# Altium schematic sheet 控制板.SchDoc(控制板)
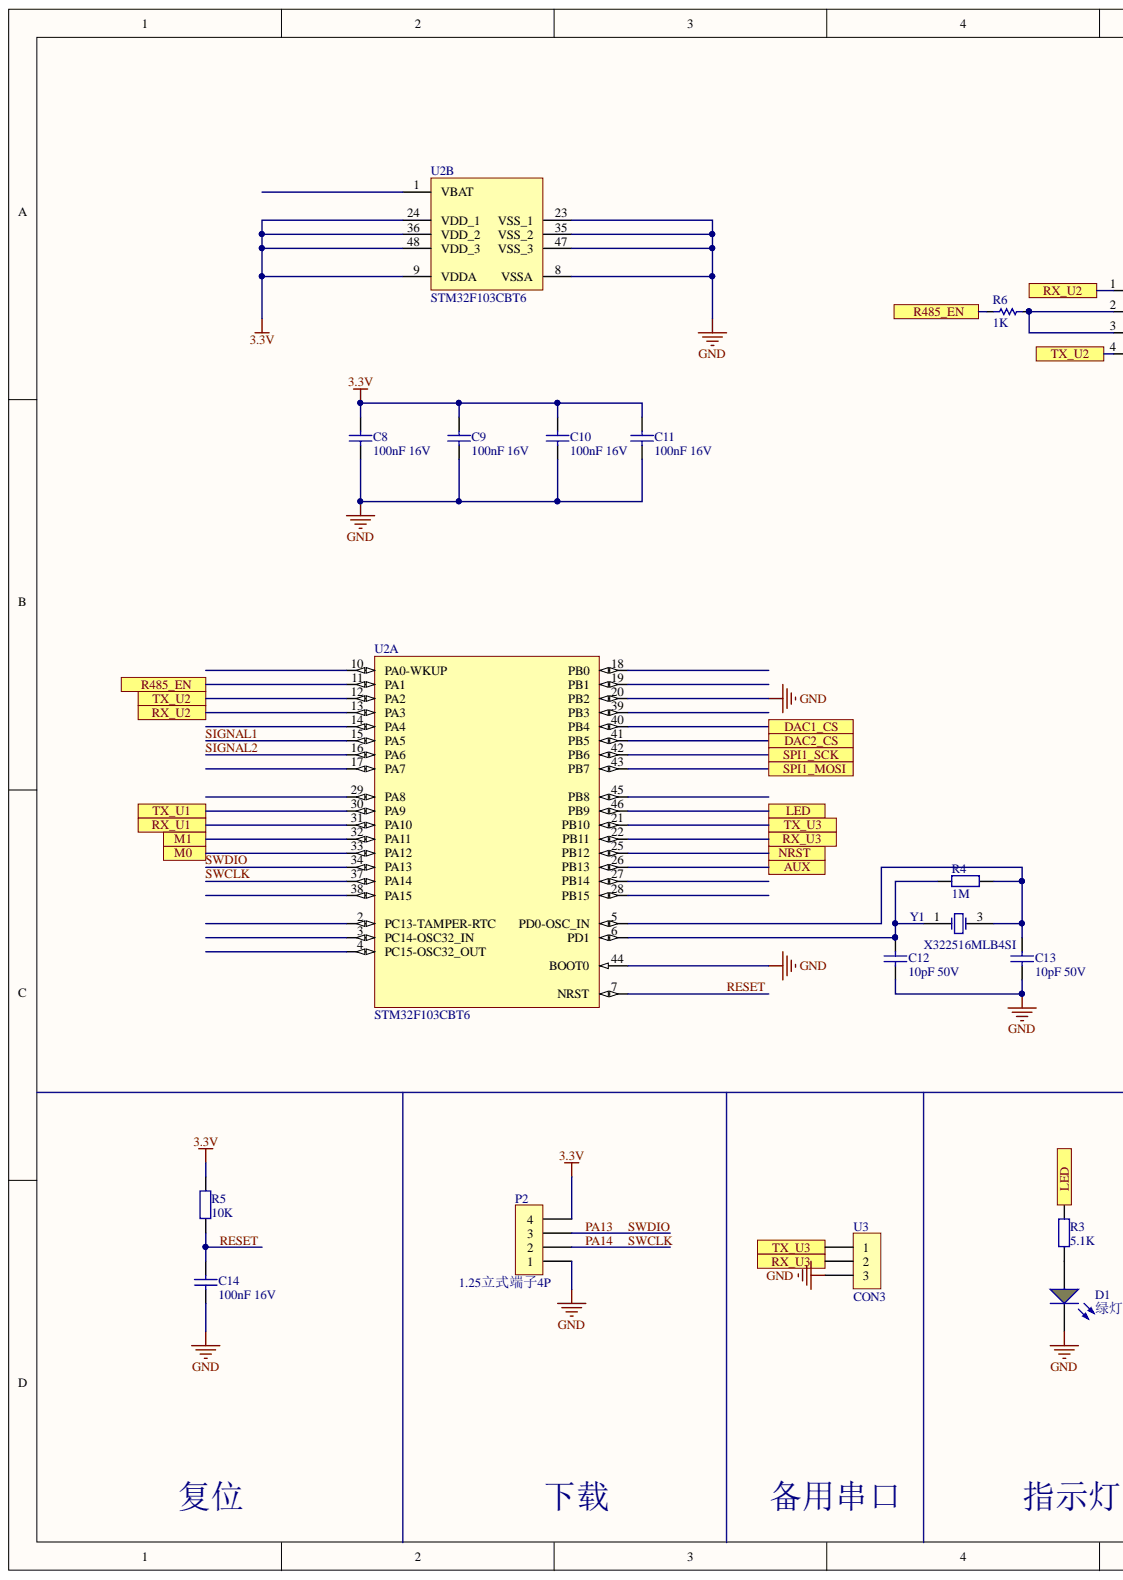
[diagram p0: 控制板.SchDoc, left]
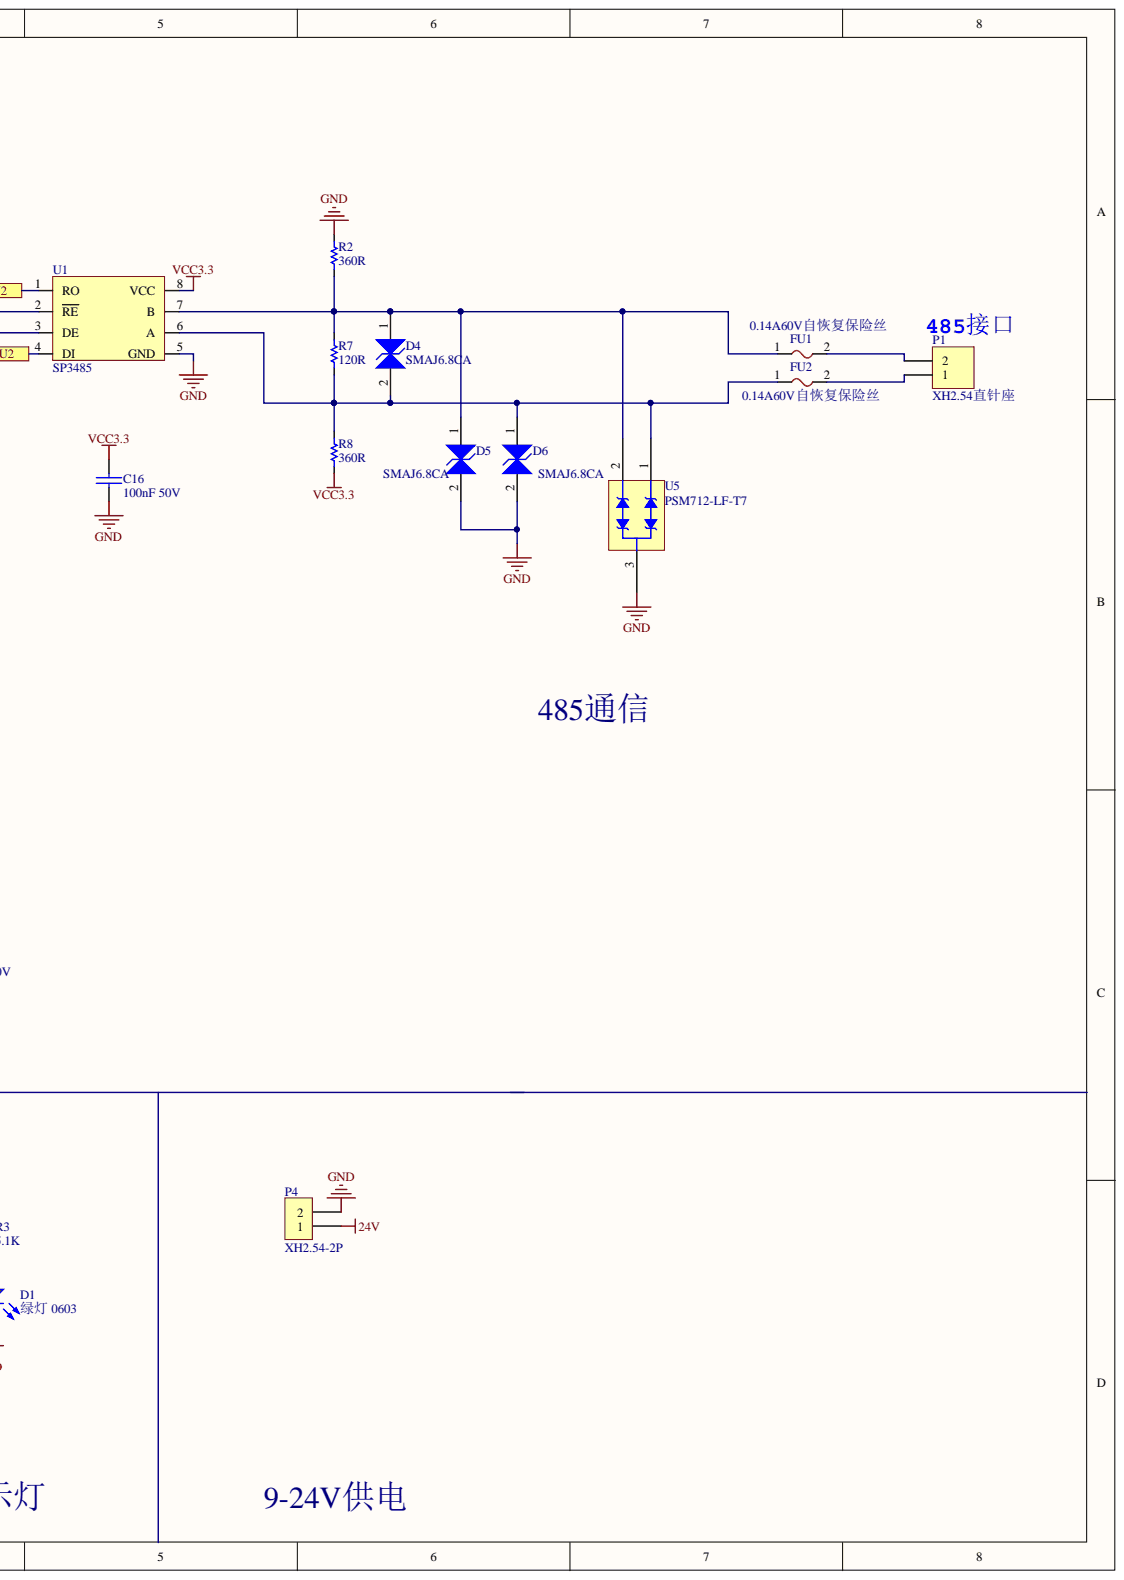
[diagram p1: 控制板.SchDoc, right]

## Parts
C8  100nF 16V  [0603_C]
C9  100nF 16V  [0603_C]
C10  100nF 16V  [0603_C]
C11  100nF 16V  [0603_C]
C12  10pF 50V  [0603_C]
C13  10pF 50V  [0603_C]
C14  100nF 16V  [0603_C]
C16  100nF 50V  [0603_C]
D1  绿灯 0603  Package: 0603  [LC-0603_LED_S1]
D4  SMAJ6.8CA  [SMA(DO-214AC)_S1]
D5  SMAJ6.8CA  [SMA(DO-214AC)_S1]
D6  SMAJ6.8CA  [SMA(DO-214AC)_S1]
FU1  0.14A60V自恢复保险丝  [1812_R]
FU2  0.14A60V自恢复保险丝  [1812_R]
P1  XH2.54直针座  [5.0-2P]
P2  1.25立式端子4P  [1.2.5/4PM]
P4  XH2.54-2P  [2.54/2PM]
R2  360R  [0603_R]
R3  5.1K  [0603_R]
R4  1M  [0603_R]
R5  10K  [0603_R]
R6  1K  [0603_R]
R7  120R  [0603_R]
R8  360R  [0603_R]
U1  SP3485  [SOIC-8_150mil]
U2  STM32F103CBT6  [LQFP-48_7x7x05P]  (parts A,B)
U3  CON3  [SIP3]
U5  PSM712-LF-T7  [SOT-23(SOT-23-3)]
Y1  X322516MLB4SI  [SMD-3225_4P]

## Ports
AUX, DAC1_CS, DAC2_CS, LED, M0, M1, NRST, R485_EN, RX_U1, RX_U2, RX_U3, SPI1_MOSI, SPI1_SCK, TX_U1, TX_U2, TX_U3

## Nets
3.3V: C8.2 C9.2 C10.2 C11.2 P2.4 R5.2 U2.9(VDDA) U2.24(VDD_1) U2.36(VDD_2) U2.48(VDD_3)
24V: P4.1
GND: C8.1 C9.1 C10.1 C11.1 C12.1 C13.1 C14.1 C16.2 D1.K D5.2 D6.2 P2.1 P4.2 R2.2 U1.5(GND) U2.8(VSSA) U2.20(PB2) U2.23(VSS_1) U2.35(VSS_2) U2.44(BOOT0) U2.47(VSS_3) U3.3 U5.3
VCC3.3: C16.1 R8.1 U1.8(VCC)
AUX: U2.26(PB13) ; port AUX
DAC1_CS: U2.40(PB4) ; port DAC1_CS
DAC2_CS: U2.41(PB5) ; port DAC2_CS
LED: R3.2 U2.46(PB9) ; port LED
M0: U2.33(PA12) ; port M0
M1: U2.32(PA11) ; port M1
NRST: U2.25(PB12) ; port NRST
PA13: P2.3 U2.34(PA13)
PA14: P2.2 U2.37(PA14)
R485_EN: R6.2 U2.11(PA1) ; port R485_EN
RESET: C14.2 R5.1 U2.7(NRST)
RX_U1: U2.31(PA10) ; port RX_U1
RX_U2: U1.1(RO) U2.13(PA3) ; port RX_U2
RX_U3: U2.22(PB11) U3.2 ; port RX_U3
SIGNAL1: U2.15(PA5)
SIGNAL2: U2.16(PA6)
SPI1_MOSI: U2.43(PB7) ; port SPI1_MOSI
SPI1_SCK: U2.42(PB6) ; port SPI1_SCK
TX_U1: U2.30(PA9) ; port TX_U1
TX_U2: U1.4(DI) U2.12(PA2) ; port TX_U2
TX_U3: U2.21(PB10) U3.1 ; port TX_U3
NetC12_2: C12.2 R4.1 U2.6(PD1) Y1.1
NetC13_2: C13.2 R4.2 U2.5(PD0-OSC_IN) Y1.3
NetD1_A: D1.A R3.1
NetD4_1: D4.1 D5.1 FU1.1 R2.1 R7.1 U1.7(B) U5.2
NetD4_2: D4.2 D6.1 FU2.1 R7.2 R8.2 U1.6(A) U5.1
NetFU1_2: FU1.2 P1.2
NetFU2_2: FU2.2 P1.1
NetR6_1: R6.1 U1.2(RE) U1.3(DE)
N00078:

Not connected: U2 1(VBAT) 2(PC13-TAMPER-RTC) 3(PC14-OSC32_IN) 4(PC15-OSC32_OUT) 10(PA0-WKUP) 14(PA4) 17(PA7) 18(PB0) 19(PB1) 27(PB14) 28(PB15) 29(PA8) 38(PA15) 39(PB3) 45(PB8)

## Library data of the parts
C8-C11 C14 = 0402 100nF (104) 10% 16V: 贴片电容; SuppliersPartNumber: C1525; Supplier: LC; Package: 0402; Library Name: Cap.SchLib; ComponentLink1URL: http://www.szlcsc.com/product/details_1877.html; ComponentLink1Description: 供应商链接; Component Kind: Standard
C12 C13 = 0402 10pF (100) 5% 50V: 贴片电容; SuppliersPartNumber: C32949; Supplier: LC; Package: 0402; Library Name: Cap.SchLib; ComponentLink1URL: http://www.szlcsc.com/product/details_33914.html; ComponentLink1Description: 供应商链接; Component Kind: Standard
C16 = CAP: Component Kind: Standard; Library Name: wgh元件库.SCHLIB
D1 = 0603 绿灯: 发光二极管; SuppliersPartNumber: C72043; Supplier: LC; ComponentLink1URL: http://www.szlcsc.com/product/details_73152.html; ComponentLink1Description: 供应商链接; Component Kind: Standard; Library Name: wgh元件库.SCHLIB
D4-D6 = SMB/DO-214AA P6SMB6.8CA: TVS; SuppliersPartNumber: C78395; Supplier: LC; Package: SMB/DO-214AA; Library Name: Diode.SchLib; ComponentLink1URL: http://www.szlcsc.com/product/details_79530.html; ComponentLink1Description: 供应商链接; Component Kind: Standard
FU1 FU2 = 自恢复保险丝: Component Kind: Standard; Library Name: wgh元件库.SCHLIB
P1 = Header 2: Header, 2-Pin; LatestRevisionNote: Re-released for DXP Platform.; LatestRevisionDate: 17-Jul-2002; Component Kind: Standard; Publisher: Altium Limited; Library Name: MAMA.SCHLIB
P2 = CON4: Component Kind: Standard; Library Name: wgh元件库.SCHLIB
P4 = CON2: Component Kind: Standard; Library Name: wgh元件库.SCHLIB
R2 R6-R8 = Res: Resistor; Value: 68.1K; Simulation: RESISTOR; Published: 8-Jun-2000; PackageReference: AXIAL-0.3; PackageDescription: Axial Device, Thru-Hole; 2 Leads; 0.3 in Pin Spacing; LatestRevisionNote: Re-released for DXP Platform.; LatestRevisionDate: 17-Jul-2002; Component Kind: Standard; Publisher: Altium Limited; Library Name: MAMA.SCHLIB
R3 = 0402 1KΩ (1001) 1%: 贴片电阻; SuppliersPartNumber: C11702; Supplier: LC; Package: 0402; Library Name: RES.SchLib; ComponentLink1URL: http://www.szlcsc.com/product/details_12256.html; ComponentLink1Description: 供应商链接; Component Kind: Standard
R4 = 0402 1MΩ (1004) 1%: 贴片电阻; SuppliersPartNumber: C26083; Supplier: LC; Package: 0402; Library Name: RES.SchLib; ComponentLink1URL: http://www.szlcsc.com/product/details_26826.html; ComponentLink1Description: 供应商链接; Component Kind: Standard
R5 = 0603 20KΩ (2002) 1%: 贴片电阻; SuppliersPartNumber: C4184; Supplier: LC; Package: 0603; Library Name: RES.SchLib; ComponentLink1URL: http://www.szlcsc.com/product/details_4591.html; ComponentLink1Description: 供应商链接; Component Kind: Standard
U2 = STM32F103CBT6: ARM; SuppliersPartNumber: C8304; Supplier: LC; Package: LQFP-48_7x7x05P; Library Name: MCU.SchLib; ComponentLink1URL: http://www.szlcsc.com/product/details_8796.html; ComponentLink1Description: 供应商链接; Component Kind: Standard
U5 = PSM712-LF-T7_[C32677]: TVS; SuppliersPartNumber: C32677; Supplier: LC; Package: SOT-23(SOT-23-3); Library Name: IC.SchLib; ComponentLink1URL: http://www.szlcsc.com/product/details_33642.html; ComponentLink1Description: 供应商链接; Component Kind: Standard
Y1 = SMD-3225_4P  16MHZ 9PF 10PPM: 16MHZ晶振; SuppliersPartNumber: C13738; Supplier: LC; Package: SMD-3225_4P; Library Name: CrystalOscillator.SchLib; ComponentLink1URL: http://www.szlcsc.com/product/details_14393.html; ComponentLink1Description: 供应商链接; Component Kind: Standard
U1 = SP3485: Component Kind: Standard; Library Name: wgh元件库.SCHLIB
U3 = CON3: Component Kind: Standard; Library Name: wgh元件库.SCHLIB
